# Altium schematic sheet flexPCB.SchDoc(flexPCB)
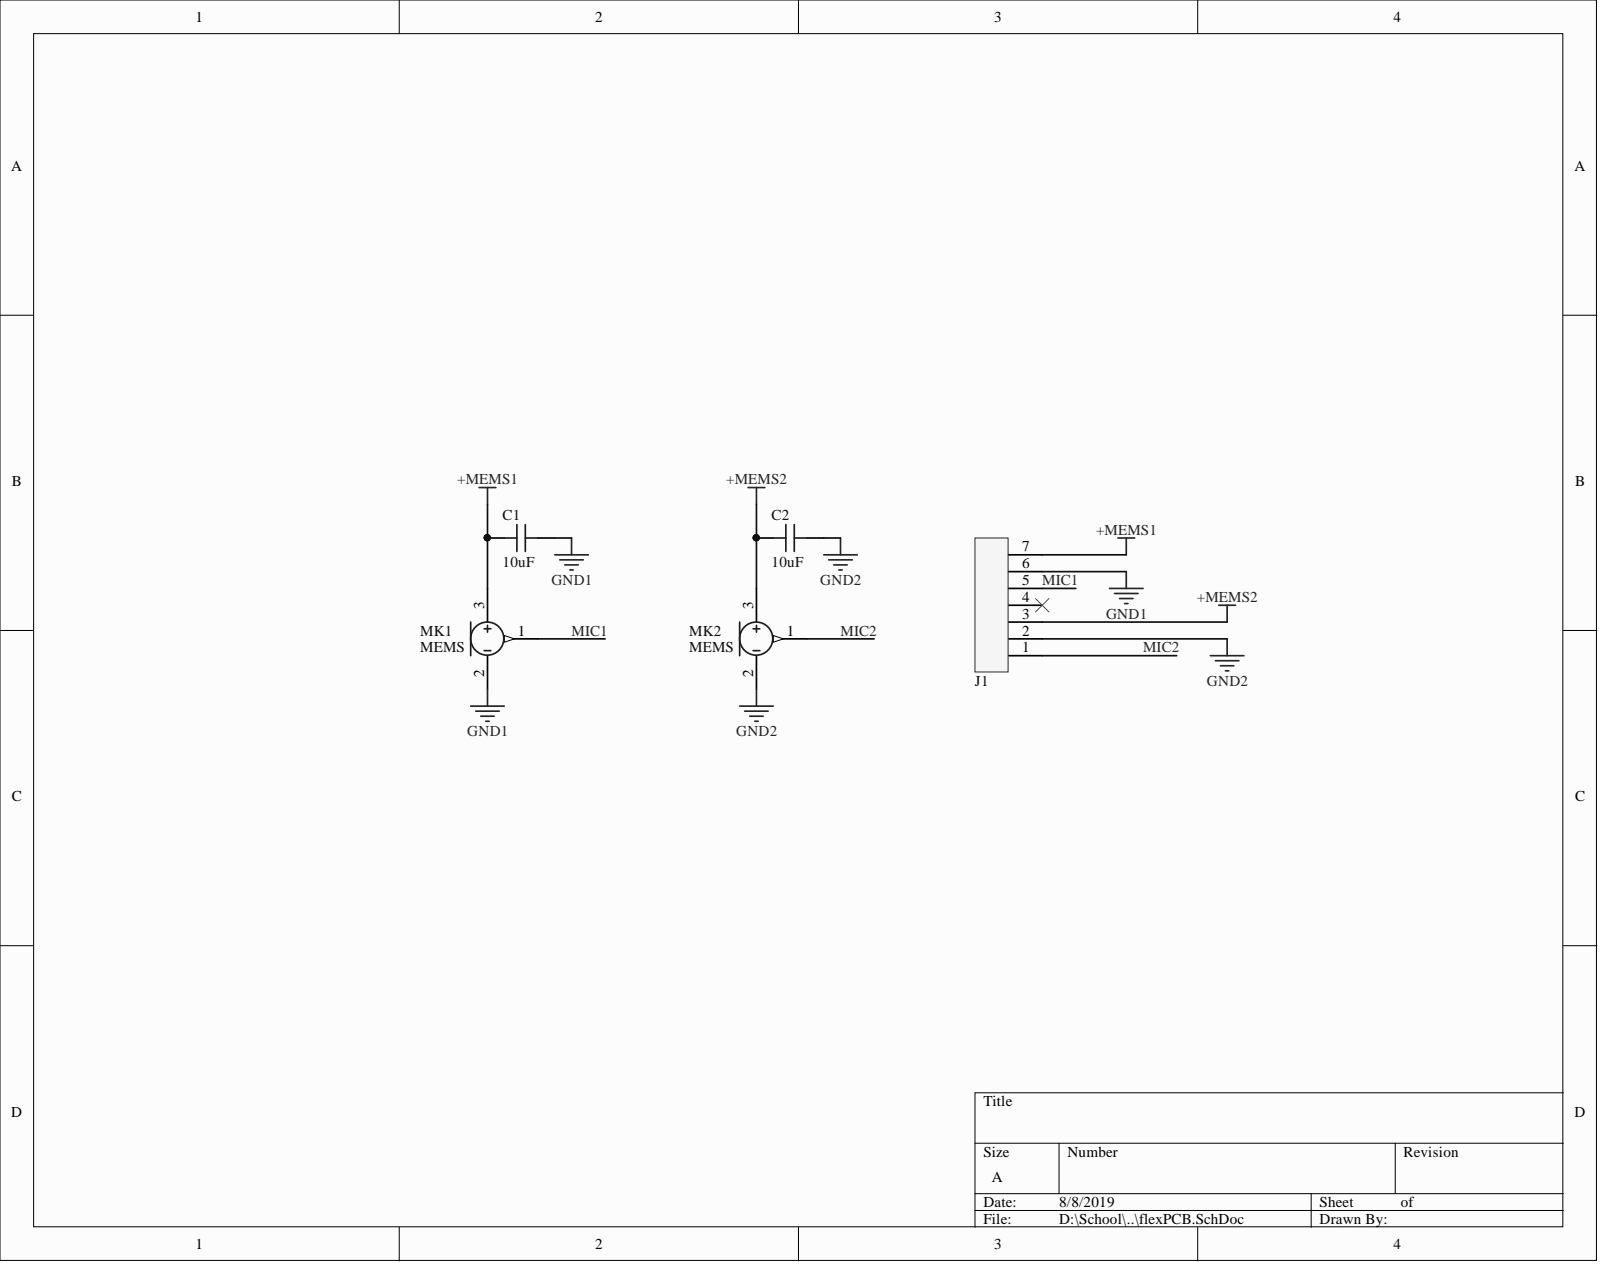
[diagram p0: flexPCB.SchDoc]

## Parts
C1  10uF  [CAP 0402/1005]
C2  10uF  [CAP 0402/1005]
J1  FFC EDGE BOTTOM  [HIROSE_FH58M-7S-0.25SHW-99_BOTTOMFFC]  (value not drawn)
MK1  MEMS  [MIC_CAGRI]
MK2  MEMS  [MIC_CAGRI]

## Nets
+MEMS1: C1.1 J1.7 MK1.3
+MEMS2: C2.1 J1.3 MK2.3
GND1: C1.2 J1.6 MK1.2
GND2: C2.2 J1.2 MK2.2
MIC1: J1.5 MK1.1
MIC2: J1.1 MK2.1

Not connected: J1 4

## Library data of the parts
C1 C2 = C1005X5R0J106M050BC: CAP CER 10UF 6.3V X5R 0402; Manufacturer: TDK Corporation; Tolerance: 20%; TemperatureRange: -55C ~ 85C; Database Table Name: Capacitor - Ceramic; Voltage: 6.3V; Value: 10uF; TemperatureCoefficient: X5R; Supplier Part Number 1: 445-8920-2-ND; Supplier 1: Digi-Key; Price: 0.119; Part Number: C1005X5R0J106M050BC; PartId: 12380; Library Name: Celestial Library Database.DbLib; Device Package: 0402 (1005 Metric); ComponentLink2URL: https://www.digikey.com/product-detail/en/tdk-corporation/C1005X5R0J106M050BC/445-8920-2-ND/3507809; ComponentLink2Description: Digi-Key Listing; ComponentLink1URL: https://product.tdk.com/info/en/catalog/datasheets/mlcc_commercial_general_en.pdf; ComponentLink1Description: Datasheet; Component Kind: Standard
J1 = HIROSE_FH58M-7S-0.25SHW-99_BOTTOM: Bottom FFC Land Pattern for Hirose FH58M-7S-0.25SHW(99) 7-pin Top+Bottom Contact FFC Connector; Component Kind: Standard; Library Name: OSP_Symbols.SchLib
MK1 MK2 = Mems_Mic_Cagri: Generic 3-pin bottom mount MEMS mic, with Cagri extended footprint; Component Kind: Standard; Library Name: OSP_Symbols.SchLib
